# Altium schematic sheet arm.SchDoc
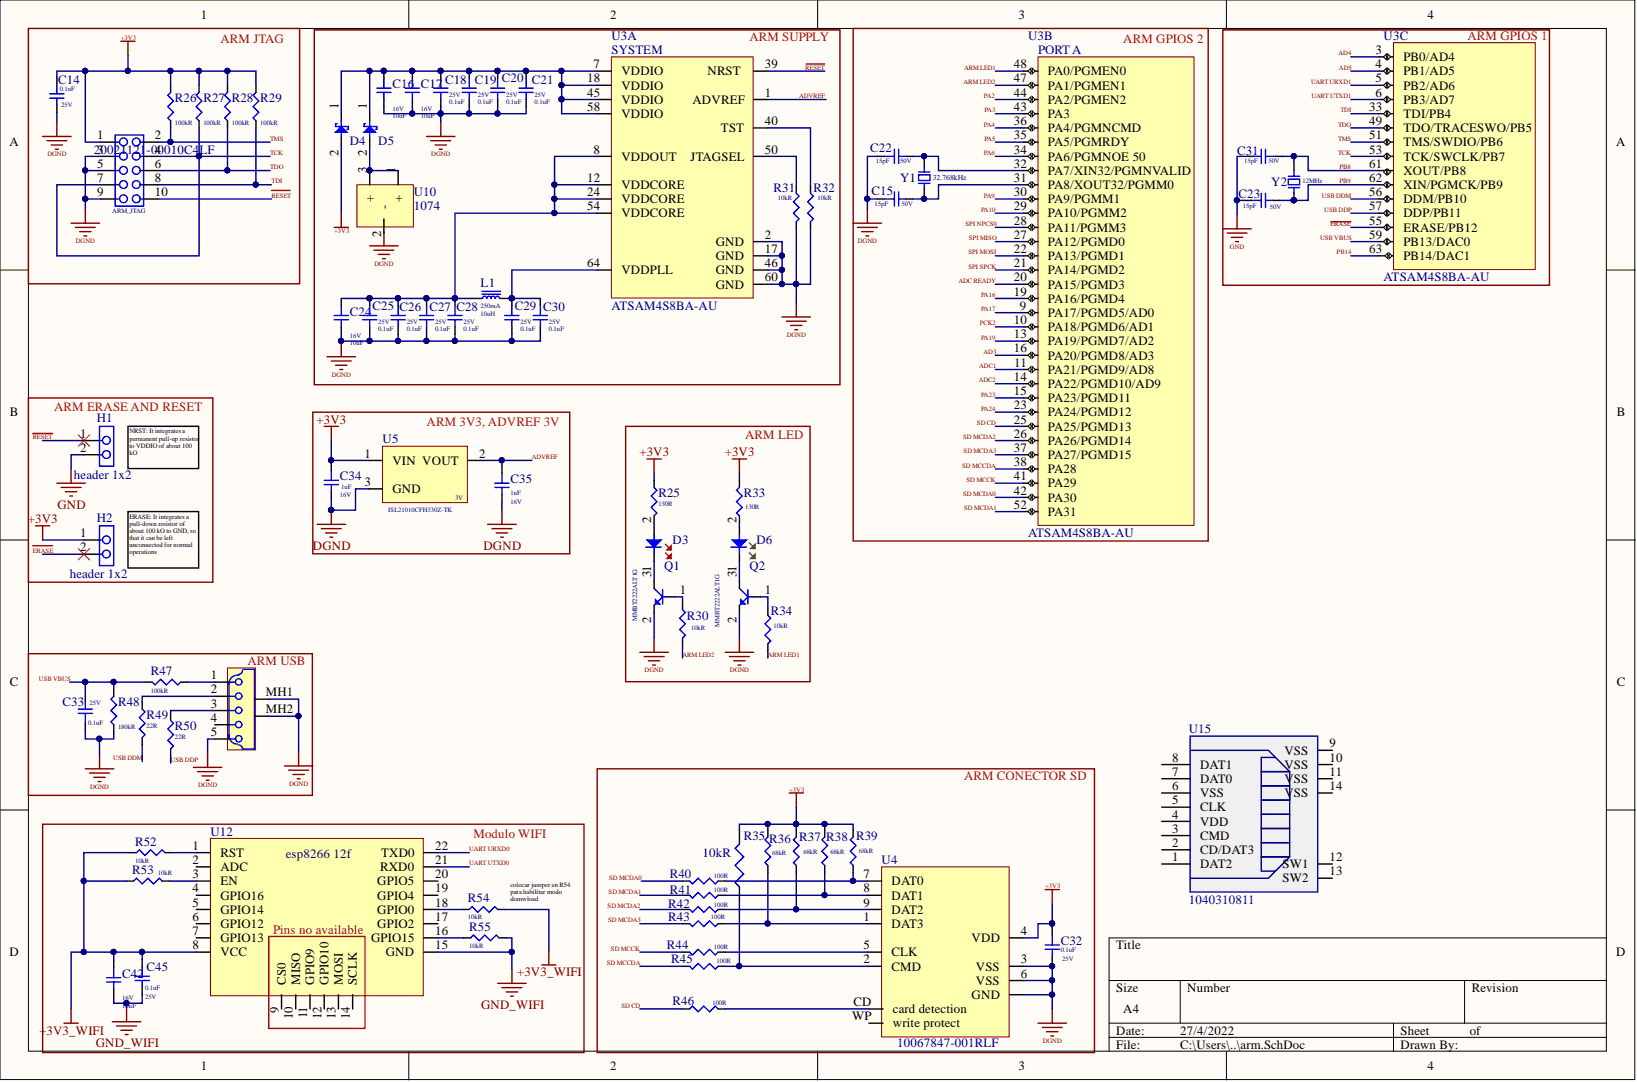
[diagram p0: arm.SchDoc]

## Parts
ARM_JTAG  20021121-00010C4LF  [conector jtag ok]
C14  Capacitance: 0.1uF  Voltage Rating: 25V  [CAP smd 0805 ok]  (hidden comment: CAP_CC0805KRX7R8BB104_YAGEO)
C15  Capacitance: 15pF  Voltage Rating: 50V  [CAP smd 0805 ok]  (hidden comment: CAP_C0805X150J5GACTU_KEMET)
C16  Capacitance: 10uF  Voltage Rating: 16V  [CAP smd 0805 OK]  (hidden comment: CAP_CL21A106KOCL3RC)
C17  Capacitance: 10uF  Voltage Rating: 16V  [CAP smd 0805 OK]  (hidden comment: CAP_CL21A106KOCL3RC)
C18  Capacitance: 0.1uF  Voltage Rating: 25V  [CAP smd 0805 ok]  (hidden comment: CAP_CC0805KRX7R8BB104_YAGEO)
C19  Capacitance: 0.1uF  Voltage Rating: 25V  [CAP smd 0805 ok]  (hidden comment: CAP_CC0805KRX7R8BB104_YAGEO)
C20  Capacitance: 0.1uF  Voltage Rating: 25V  [CAP smd 0805 ok]  (hidden comment: CAP_CC0805KRX7R8BB104_YAGEO)
C21  Capacitance: 0.1uF  Voltage Rating: 25V  [CAP smd 0805 ok]  (hidden comment: CAP_CC0805KRX7R8BB104_YAGEO)
C22  Capacitance: 15pF  Voltage Rating: 50V  [CAP smd 0805 ok]  (hidden comment: CAP_C0805X150J5GACTU_KEMET)
C23  Capacitance: 15pF  Voltage Rating: 50V  [CAP smd 0805 ok]  (hidden comment: CAP_C0805X150J5GACTU_KEMET)
C24  Capacitance: 10uF  Voltage Rating: 16V  [CAP smd 0805 OK]  (hidden comment: CAP_CL21A106KOCL3RC)
C25  Capacitance: 0.1uF  Voltage Rating: 25V  [CAP smd 0805 ok]  (hidden comment: CAP_CC0805KRX7R8BB104_YAGEO)
C26  Capacitance: 0.1uF  Voltage Rating: 25V  [CAP smd 0805 ok]  (hidden comment: CAP_CC0805KRX7R8BB104_YAGEO)
C27  Capacitance: 0.1uF  Voltage Rating: 25V  [CAP smd 0805 ok]  (hidden comment: CAP_CC0805KRX7R8BB104_YAGEO)
C28  Capacitance: 0.1uF  Voltage Rating: 25V  [CAP smd 0805 ok]  (hidden comment: CAP_CC0805KRX7R8BB104_YAGEO)
C29  Capacitance: 0.1uF  Voltage Rating: 25V  [CAP smd 0805 ok]  (hidden comment: CAP_CC0805KRX7R8BB104_YAGEO)
C30  Capacitance: 0.1uF  Voltage Rating: 25V  [CAP smd 0805 ok]  (hidden comment: CAP_CC0805KRX7R8BB104_YAGEO)
C31  Capacitance: 15pF  Voltage Rating: 50V  [CAP smd 0805 ok]  (hidden comment: CAP_C0805X150J5GACTU_KEMET)
C32  Capacitance: 0.1uF  Voltage Rating: 25V  [CAP smd 0805 ok]  (hidden comment: CAP_CC0805KRX7R8BB104_YAGEO)
C33  Capacitance: 0.1uF  Voltage Rating: 25V  [CAP smd 0805 ok]  (hidden comment: CAP_CC0805KRX7R8BB104_YAGEO)
C34  Capacitance: 1uF  Voltage Rating: 16V  [CAP smd 0805 ok]  (hidden comment: CAP_CC0805KKX7R7BB105_YAGEO)
C35  Capacitance: 1uF  Voltage Rating: 16V  [CAP smd 0805 ok]  (hidden comment: CAP_CC0805KKX7R7BB105_YAGEO)
C42  Capacitance: 10uF  Voltage Rating: 16V  [CAP smd 0805 OK]  (hidden comment: CAP_CL21A106KOCL3RC)
C45  Capacitance: 0.1uF  Voltage Rating: 25V  [CAP smd 0805 ok]  (hidden comment: CAP_CC0805KRX7R8BB104_YAGEO)
D3  150080RS75000  [Diode smd 0805 red ok]  (value not drawn)
D4  DB2J20900L  [Diode SMini2 ok]  (value not drawn)
D5  DB2J20900L  [Diode SMini2 ok]  (value not drawn)
D6  150080VS75000  [Diode smd 0805 green ok]  (value not drawn)
H1  header 1x2
H2  header 1x2
L1  Current Rating: 250mA  [Inductor smd 1812 ok]  (hidden comment: CM453232-100KL)
Q1  MMBT2222ALT1G  [transistor led ok]
Q2  MMBT2222ALT1G  [transistor led ok]
R25  Resistance: 130R  [res 0805 ok]  (hidden comment: RC0805FR-07130RL)
R26  Resistance: 100kR  [res 0805 ok]  (hidden comment: AC0805JR-07100KL)
R27  Resistance: 100kR  [res 0805 ok]  (hidden comment: AC0805JR-07100KL)
R28  Resistance: 100kR  [res 0805 ok]  (hidden comment: AC0805JR-07100KL)
R29  Resistance: 100kR  [res 0805 ok]  (hidden comment: AC0805JR-07100KL)
R30  Resistance: 10kR  [res 0805 ok]  (hidden comment: RC0805JR-0710KL)
R31  Resistance: 10kR  [res 0805 ok]  (hidden comment: RC0805JR-0710KL)
R32  Resistance: 10kR  [res 0805 ok]  (hidden comment: RC0805JR-0710KL)
R33  Resistance: 130R  [res 0805 ok]  (hidden comment: RC0805FR-07130RL)
R34  Resistance: 10kR  [res 0805 ok]  (hidden comment: RC0805JR-0710KL)
R35  Resistance: 10kR  [Res 1206 ok]  (hidden comment: RC1206JR-0768KL)
R36  Resistance: 68kR  [Res 1206 ok]  (hidden comment: RC1206JR-0768KL)
R37  Resistance: 68kR  [Res 1206 ok]  (hidden comment: RC1206JR-0768KL)
R38  Resistance: 68kR  [Res 1206 ok]  (hidden comment: RC1206JR-0768KL)
R39  Resistance: 68kR  [Res 1206 ok]  (hidden comment: RC1206JR-0768KL)
R40  Resistance: 100R  [Res 1206 ok]  (hidden comment: RC1206JR-07100RL)
R41  Resistance: 100R  [Res 1206 ok]  (hidden comment: RC1206JR-07100RL)
R42  Resistance: 100R  [Res 1206 ok]  (hidden comment: RC1206JR-07100RL)
R43  Resistance: 100R  [Res 1206 ok]  (hidden comment: RC1206JR-07100RL)
R44  Resistance: 100R  [Res 1206 ok]  (hidden comment: RC1206JR-07100RL)
R45  Resistance: 100R  [Res 1206 ok]  (hidden comment: RC1206JR-07100RL)
R46  Resistance: 100R  [Res 1206 ok]  (hidden comment: RC1206JR-07100RL)
R47  Resistance: 100kR  [res 0805 ok]  (hidden comment: AC0805JR-07100KL)
R48  Resistance: 180kR  [Res 1206 ok]  (hidden comment: RC1206JR-07180KL)
R49  Resistance: 22R  [res 0805 ok]  (hidden comment: RC0805JR-0722RL)
R50  Resistance: 22R  [res 0805 ok]  (hidden comment: RC0805JR-0722RL)
R52  Resistance: 10kR  [res 0805 ok]  (hidden comment: RC0805JR-0710KL)
R53  Resistance: 10kR  [res 0805 ok]  (hidden comment: RC0805JR-0710KL)
R54  Resistance: 10kR  [res 0805 ok]  (hidden comment: RC0805JR-0710KL)
R55  Resistance: 10kR  [res 0805 ok]  (hidden comment: RC0805JR-0710KL)
U3  ATSAM4S8BA-AU  Core Architecture: ARM  [arm ok]  (parts A,B,C)
U4  10067847-001RLF  [conector sd ok]
U5  ISL21010CFH330Z-TK  Max Output Voltage: 3V  [3v reference ok]
U9  UX60SC-MB-5S8  (value not drawn)
U10  1074  [pila]
U12  esp8266 12f  [modulo wifi ok]
U15  1040310811  [micro sd]
Y1  Frequency: 32.768kHz  [clk 32k ok]  (hidden comment: ECS-.327-12.5-34S-TR)
Y2  Frequency: 12MHz  [clk 12M ok]  (hidden comment: ECS-120-20-3X-TR)

## Nets
+3V3: ARM_JTAG.1 C14.23 C32.23 C34.23 D4.2 H2.1 R25.1 R26.2 R27.2 R28.2 R29.2 R33.2 R35.1 R36.1 R37.1 R38.1 R39.1 U4.4(VDD) U5.1
+3V3_WIFI: C42.23 C45.23 R52.2 R53.2 R54.1 U12.8(VCC)
DGND: ARM_JTAG.3 ARM_JTAG.5 ARM_JTAG.9 C14.22 C15.23 C16.22 C17.22 C18.22 C19.22 C20.22 C21.22 C22.23 C24.22 C25.22 C26.22 C27.22 C28.22 C29.22 C30.22 C32.22 C33.23 C34.22 C35.22 Q1.2 Q2.2 R31.1 R32.1 R48.2 U3.2(GND) U3.17(GND) U3.46(GND) U3.60(GND) U4.3(VSS) U4.6(VSS) U4.GND U5.3 U9.5 U9.MH1 U9.MH2
GND: C23.23 C31.22 H1.2
GND_WIFI: C42.22 C45.22 R55.1 U12.15(GND)
AD3: U3.16(PA20/PGMD8/AD3)
AD4: U3.3(PB0/AD4)
AD5: U3.4(PB1/AD5)
ADC1: U3.11(PA21/PGMD9/AD8)
ADC2: U3.14(PA22/PGMD10/AD9)
ADC READY: U3.20(PA15/PGMD3)
ADVREF: C35.23 U3.1(ADVREF) U5.2
ARM LED1: R34.1 U3.48(PA0/PGMEN0)
ARM LED2: R30.1 U3.47(PA1/PGMEN1)
ERASE: H2.2 U3.55(ERASE/PB12)
PA2: U3.44(PA2/PGMEN2)
PA3: U3.43(PA3)
PA4: U3.36(PA4/PGMNCMD)
PA5: U3.35(PA5/PGMRDY)
PA6: U3.34(PA6/PGMNOE_50)
PA9: U3.30(PA9/PGMM1)
PA10: U3.29(PA10/PGMM2)
PA16: U3.19(PA16/PGMD4)
PA17: U3.9(PA17/PGMD5/AD0)
PA19: U3.13(PA19/PGMD7/AD2)
PA23: U3.15(PA23/PGMD11)
PA24: U3.23(PA24/PGMD12)
PB8: C31.23 U3.61(XOUT/PB8) Y2.14
PB9: C23.22 U3.62(XIN/PGMCK/PB9) Y2.13
PB14: U3.63(PB14/DAC1)
PCK2: U3.10(PA18/PGMD6/AD1)
RESET: ARM_JTAG.10 H1.1 U3.39(NRST)
SD CD: R46.2 U3.25(PA25/PGMD13)
SD MCCDA: R45.1 U3.38(PA28)
SD MCCK: R44.1 U3.41(PA29)
SD MCDA0: R40.1 U3.42(PA30)
SD MCDA1: R41.1 U3.52(PA31)
SD MCDA2: R42.1 U3.26(PA26/PGMD14)
SD MCDA3: R43.1 U3.37(PA27/PGMD15)
SPI MISO: U3.27(PA12/PGMD0)
SPI MOSI: U3.22(PA13/PGMD1)
SPI NPCS0: U3.28(PA11/PGMM3)
SPI SPCK: U3.21(PA14/PGMD2)
TCK: ARM_JTAG.4 ARM_JTAG.7 R27.1 U3.53(TCK/SWCLK/PB7)
TDI: ARM_JTAG.8 R29.1 U3.33(TDI/PB4)
TDO: ARM_JTAG.6 R28.1 U3.49(TDO/TRACESWO/PB5)
TMS: ARM_JTAG.2 R26.1 U3.51(TMS/SWDIO/PB6)
UART URXD0: U12.22(TXD0)
UART URXD1: U3.5(PB2/AD6)
UART UTXD0: U12.21(RXD0)
UART UTXD1: U3.6(PB3/AD7)
USB DDM: R49.1 U3.56(DDM/PB10)
USB DDP: R50.1 U3.57(DDP/PB11)
USB VBUS: C33.22 R47.2 R48.1 U3.59(PB13/DAC0)
NetC15_22: C15.22 U3.31(PA8/XOUT32/PGMM0) Y1.13
NetC16_23: C16.23 C17.23 C18.23 C19.23 C20.23 C21.23 D4.1 D5.1 U3.7(VDDIO) U3.18(VDDIO) U3.45(VDDIO) U3.58(VDDIO)
NetC22_22: C22.22 U3.32(PA7/XIN32/PGMNVALID) Y1.14
NetC24_23: C24.23 C25.23 C26.23 C27.23 C28.23 L1.1 U3.8(VDDOUT) U3.12(VDDCORE) U3.24(VDDCORE) U3.54(VDDCORE)
NetC29_23: C29.23 C30.23 L1.2 U3.64(VDDPLL)
NetD3_1: D3.1 Q1.3
NetD3_2: D3.2 R25.2
NetD5_2: D5.2 U10.1 U10.3
NetD6_1: D6.1 Q2.3
NetD6_2: D6.2 R33.1
NetQ1_1: Q1.1 R30.2
NetQ2_1: Q2.1 R34.2
NetR31_2: R31.2 U3.50(JTAGSEL)
NetR32_2: R32.2 U3.40(TST)
NetR35_2: R35.2 R45.2 U4.2(CMD)
NetR36_2: R36.2 R43.2 U4.1(DAT3)
NetR37_2: R37.2 R42.2 U4.9(DAT2)
NetR38_2: R38.2 R41.2 U4.8(DAT1)
NetR39_2: R39.2 R40.2 U4.7(DAT0)
NetR44_2: R44.2 U4.5(CLK)
NetR46_1: R46.1 U4.CD(card_detection)
NetR47_1: R47.1 U9.1
NetR49_2: R49.2 U9.2
NetR50_2: R50.2 U9.3
NetR52_1: R52.1 U12.1(RST)
NetR53_1: R53.1 U12.3(EN)
NetR54_2: R54.2 U12.18(GPIO0)
NetR55_2: R55.2 U12.16(GPIO15)

Not connected: U4 WP(write_protect); U9 4; U10 2; U12 2(ADC) 4(GPIO16) 5(GPIO14) 6(GPIO12) 7(GPIO13) 9(CS0) 10(MISO) 11(GPIO9) 12(GPIO10) 13(MOSI) 14(SCLK) 17(GPIO2) 19(GPIO4) 20(GPIO5); U15 1(DAT2) 2(CD/DAT3) 3(CMD) 4(VDD) 5(CLK) 6(VSS) 7(DAT0) 8(DAT1) 9(VSS) 10(VSS) 11(VSS) 12(SW1) 13(SW2) 14(VSS)
